# Altium schematic sheet CompoundPeripheral.SchDoc
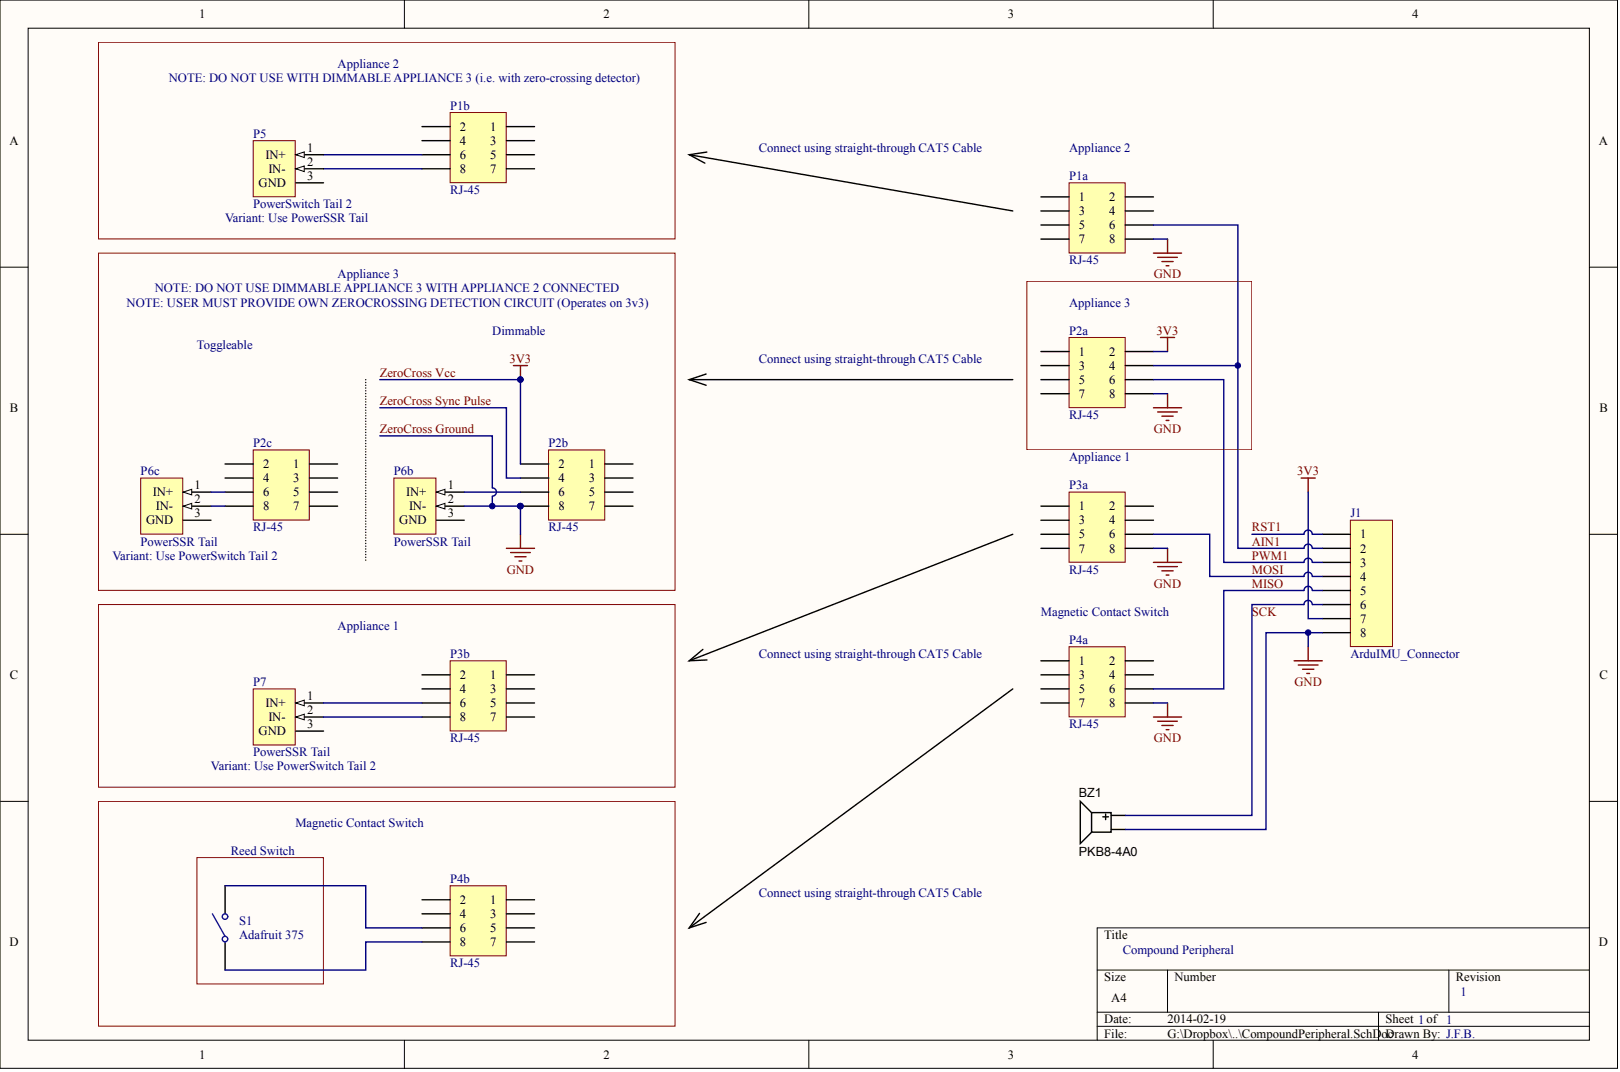
[diagram p0: CompoundPeripheral.SchDoc]

## Parts
BZ1  PKB8-4A0
J1  ArduIMU_Connector
P1a  RJ-45
P1b  RJ-45
P2a  RJ-45
P2b  RJ-45
P2c  RJ-45
P3a  RJ-45
P3b  RJ-45
P4a  RJ-45
P4b  RJ-45
P5  PowerSwitch Tail 2
P6b  PowerSSR Tail
P6c  PowerSSR Tail
P7  PowerSSR Tail
S1  Adafruit 375

## Nets
AIN1: J1.2 P1a.6 P2a.4
MISO: J1.5 P4a.6
MOSI: J1.4 P3a.6
PWM1: J1.3 P2a.6
RST1: J1.1
SCK: BZ1.1 J1.6
ZEROCROSS GROUND: BZ1.2 J1.8 P1a.8 P2a.8 P2b.8 P3a.8 P4a.8 P6b.2
ZEROCROSS SYNC PULSE: P2b.4
ZEROCROSS VCC: J1.7 P2a.2 P2b.2
NetP1b_6: P1b.6 P5.1
NetP1b_8: P1b.8 P5.2
NetP2b_6: P2b.6 P6b.1
NetP2c_6: P2c.6 P6c.1
NetP2c_8: P2c.8 P6c.2
NetP3b_6: P3b.6 P7.1
NetP3b_8: P3b.8 P7.2
NetP4b_6: P4b.6 S1.2
NetP4b_8: P4b.8 S1.1

Not connected: P1a 1 2 3 4 5 7; P1b 1 2 3 4 5 7; P2a 1 3 5 7; P2b 1 3 5 7; P2c 1 2 3 4 5 7; P3a 1 2 3 4 5 7; P3b 1 2 3 4 5 7; P4a 1 2 3 4 5 7; P4b 1 2 3 4 5 7; P5 3; P6b 3; P6c 3; P7 3

## Library data of the parts
BZ1 = (no symbol name): Manufacturer 1: CUI Inc; Ratings: 2048 ±200 Hz; SCDType: source; Package / Case: 6.5mm pitch; Material: Noryl SE1-J; Supplier 1: Digi-Key; Supplier Part Number 1: 102-1152-ND; Manufacturer Part Number 1: PKB8-4A0
J1 P1a P1b P2a P2b P2c P3a P3b P4a P4b P5 P6b P6c P7 S1 = (no symbol name)
  J1 P1a P1b P2a P2b P2c P3a P3b P4a P4b: LatestRevisionDate: 17-Jul-2002; LatestRevisionNote: Re-released for DXP Platform.
  S1: Published: 24-Mar-1999; LatestRevisionDate: 17-Jul-2002; LatestRevisionNote: Re-released for DXP Platform.; PackageReference: SPST-2; PackageDescription: Switch; 2 Leads
  J1 P1a P1b P2a P2b P2c P3a P3b P4a P4b S1: Publisher: Altium Limited
